# Altium schematic sheet SC1.0-POWER.SchDoc(SC1.0-POWER)
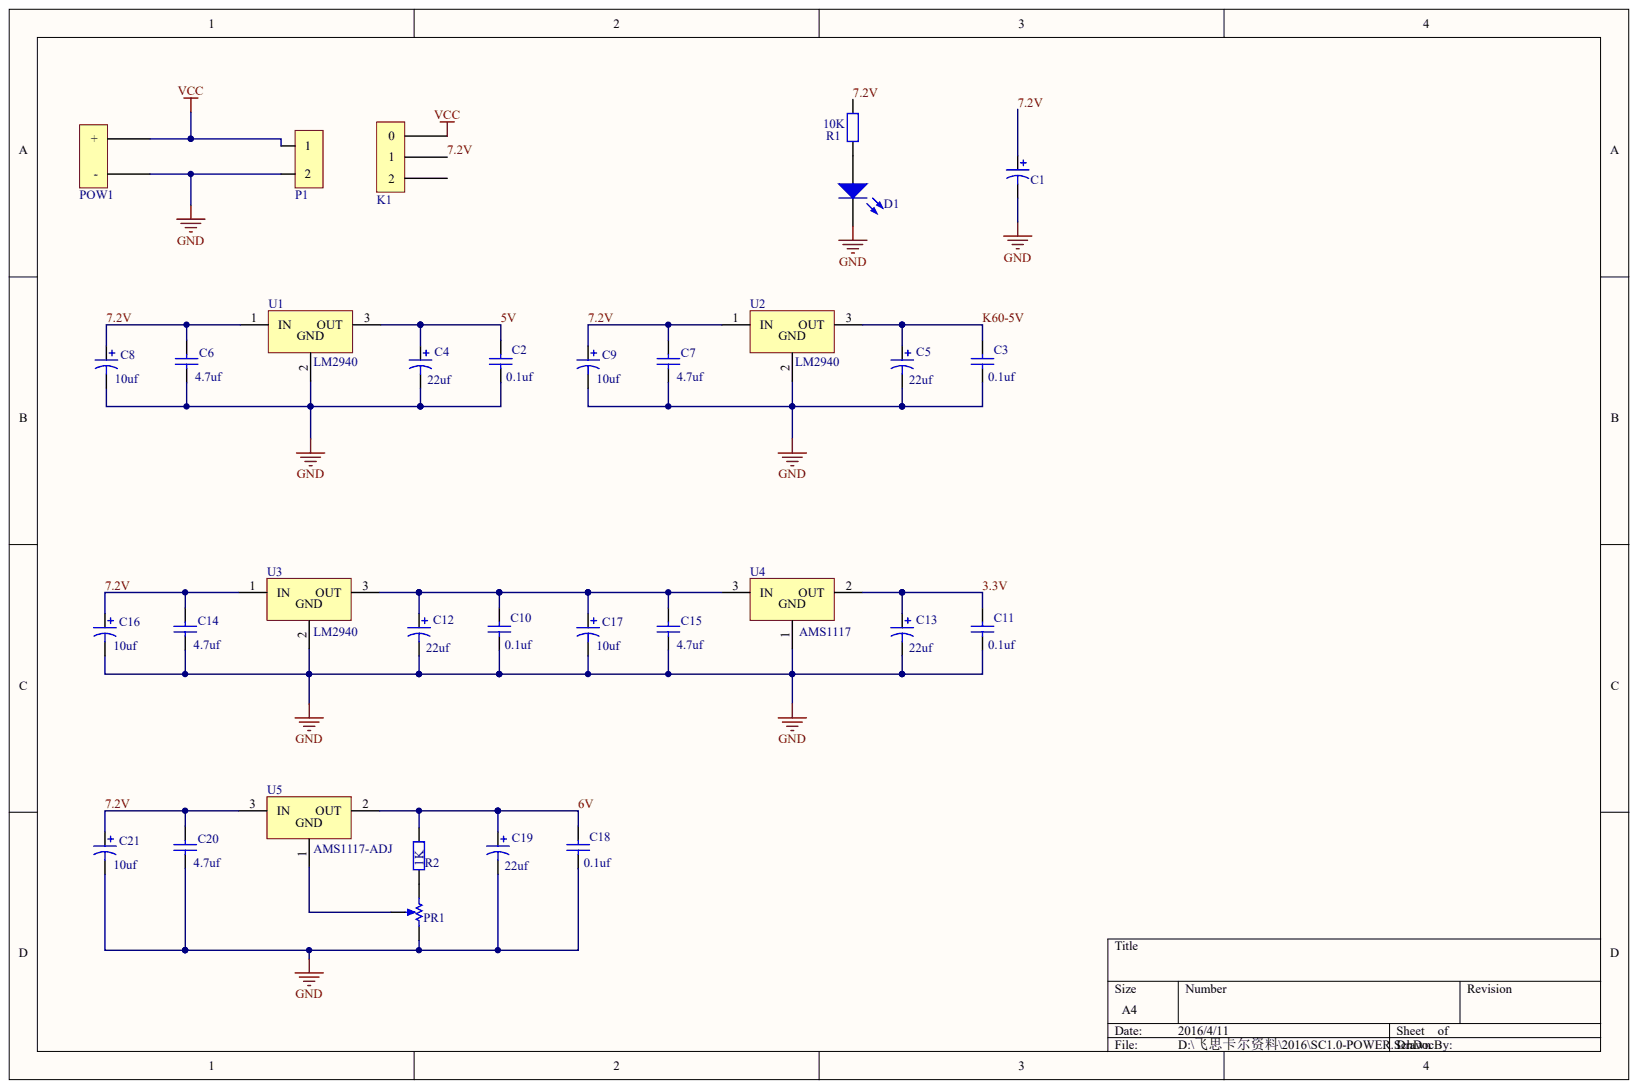
[diagram p0: SC1.0-POWER.SchDoc]

## Parts
C1  (no value)
C2  0.1uf
C3  0.1uf
C4  22uf
C5  22uf
C6  4.7uf
C7  4.7uf
C8  10uf
C9  10uf
C10  0.1uf
C11  0.1uf
C12  22uf
C13  22uf
C14  4.7uf
C15  4.7uf
C16  10uf
C17  10uf
C18  0.1uf
C19  22uf
C20  4.7uf
C21  10uf
D1  LED  (value not drawn)
K1  K
P1  Header 2  (value not drawn)
POW1  电源  (value not drawn)
PR1  电位器  (value not drawn)
R1  10K
R2  1K
U1  LM2940
U2  LM2940
U3  LM2940
U4  AMS1117
U5  AMS1117-ADJ

## Nets
GND: C1.2 C2.2 C3.2 C4.2 C5.2 C6.2 C7.2 C8.2 C9.2 C10.2 C11.2 C12.2 C13.2 C14.2 C15.2 C16.2 C17.2 C18.2 C19.2 C20.2 C21.2 D1.2 P1.2 POW1.0 PR1.3 U1.2 U2.2 U3.2 U4.1
VCC: K1.0 P1.1 POW1.1
3.3V: C11.1 C13.1 U4.2
5V: C2.1 C4.1 U1.3
6V: C18.1 C19.1 R2.2 U5.2
7.2V: C1.1 C6.1 C7.1 C8.1 C9.1 C14.1 C16.1 C20.1 C21.1 K1.1 R1.1 U1.1 U2.1 U3.1 U5.3
K60-5V: C3.1 C5.1 U2.3
NetC10_1: C10.1 C12.1 C15.1 C17.1 U3.3 U4.3
NetD1_1: D1.1 R1.2
NetPR1_1: PR1.1 R2.1
NetPR1_2: PR1.2 U5.1

Not connected: K1 2
